# Altium schematic sheet POW.SchDoc(POW)
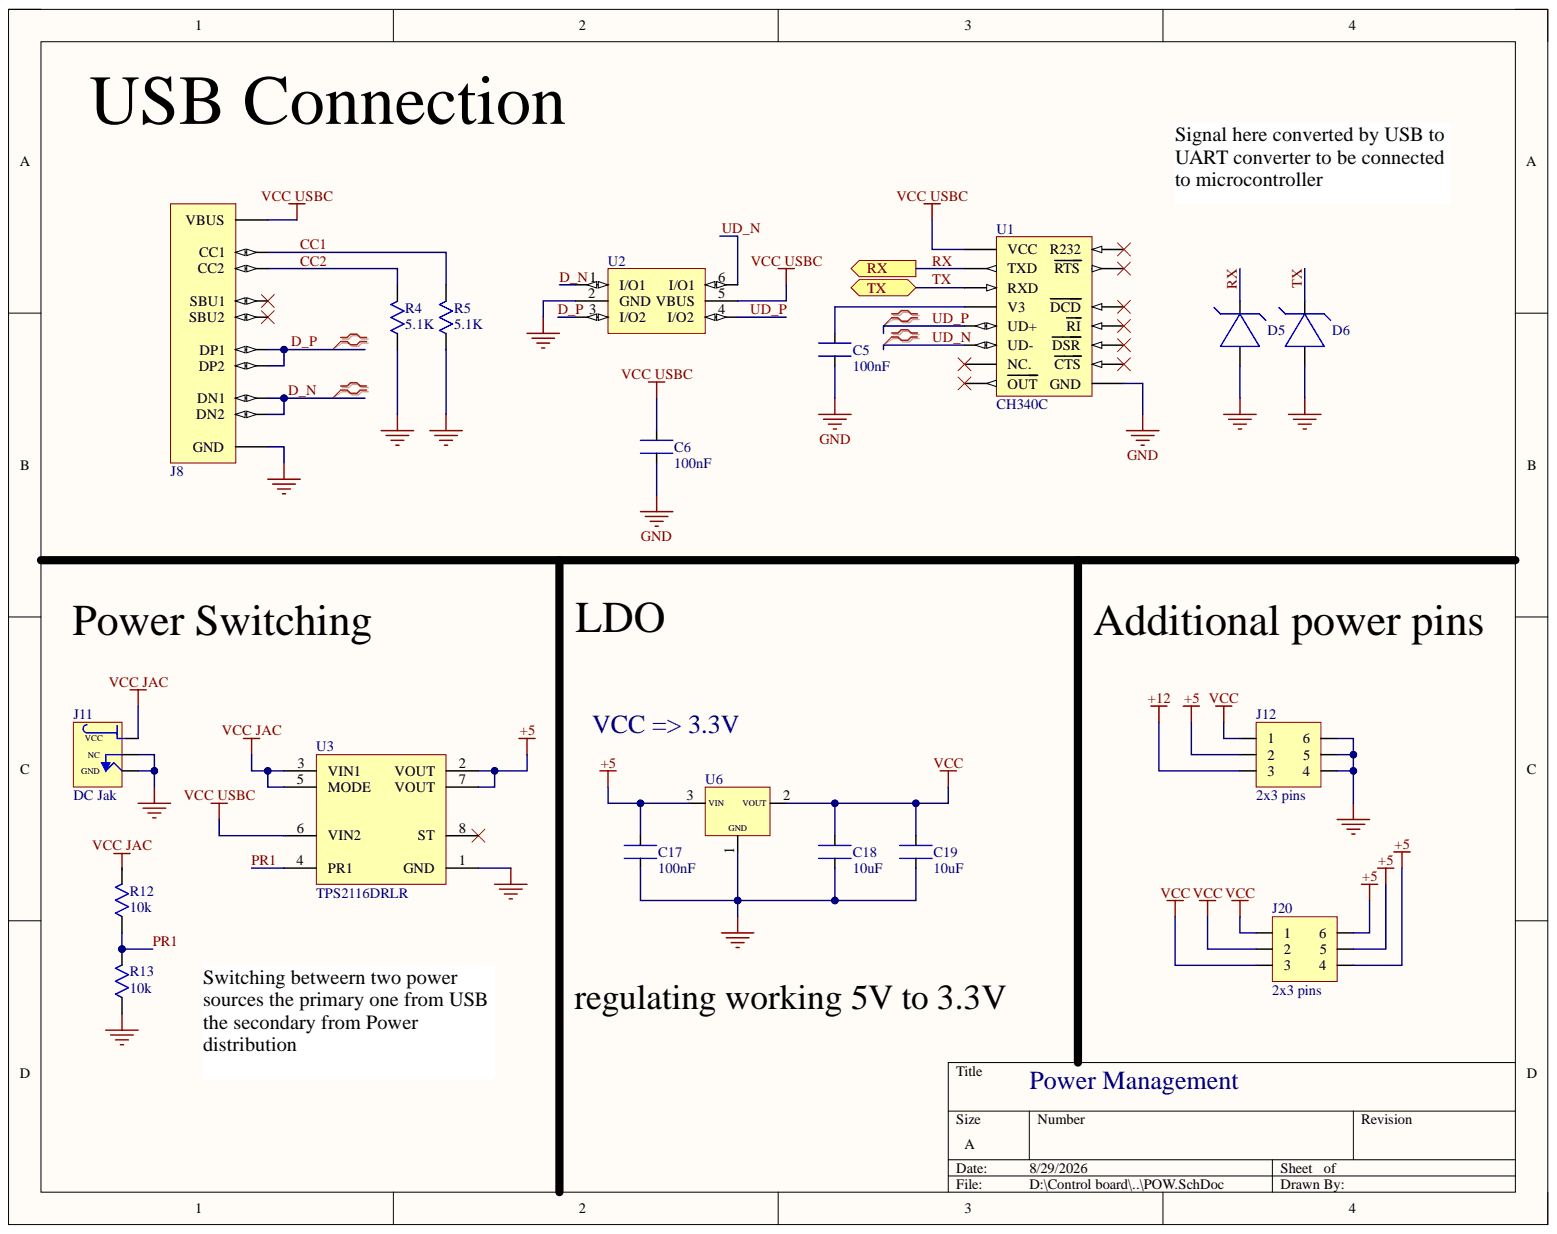
[diagram p0: POW.SchDoc]

## Parts
C5  100nF  [0603 CAP]
C6  100nF  [0603 CAP]
C17  100nF  [0603 CAP]
C18  10uF  [0603 CAP]
C19  10uF  [0603 CAP]
D5  ESD protection diode  [ESD protection diode]  (value not drawn)
D6  ESD protection diode  [ESD protection diode]  (value not drawn)
J8  USB TYPE-C-31-M-12  [USB Type-C]  (value not drawn)
J11  DC Jak  [DC Jack]
J12  2x3 pins  [2x3 pins]
J20  2x3 pins  [2x3 pins]
R4  5.1K  [0603 RES]
R5  5.1K  [0603 RES]
R12  10k  [0603 RES]
R13  10k  [0603 RES]
U1  CH340C  [CH340C]
U2  usb tybc esd  [usb c esd]  (value not drawn)
U3  TPS2116DRLR  [power distribution]
U6  ST LDO 3.3 regulator  [ST_LDO]  (value not drawn)

## Ports
RX, TX

## Nets
+5: C17.1 J12.2 J20.4 J20.5 J20.6 U3.2(VOUT) U3.7(VOUT) U6.3
+12: J12.3
GND: C5.2 C6.2 C17.2 C18.2 C19.2 D5.1 D6.1 J8.0(GND) J11.2 J11.3 J12.4 J12.5 J12.6 R4.1 R5.1 R13.1 U1.1(GND) U2.2(GND) U3.1(GND) U6.1
VCC: C18.1 C19.1 J12.1 J20.1 J20.2 J20.3 U6.2
VCC JAC: J11.1 R12.2 U3.3(VIN1) U3.5(MODE)
VCC USBC: C6.1 J8.VBUS U1.16(VCC) U2.5(VBUS) U3.6(VIN2)
CC1: J8.A5(CC1) R5.2
CC2: J8.B5(CC2) R4.2
D_N: J8.A7(DN1) J8.B7(DN2) U2.1(I/O1)
D_P: J8.A6(DP1) J8.B6(DP2) U2.3(I/O2)
PR1: R12.1 R13.2 U3.4(PR1)
RX: D5.2 U1.2(TXD) ; port RX
TX: D6.2 U1.3(RXD) ; port TX
UD_N: U1.6(UD-) U2.6(I/O1)
UD_P: U1.5(UD+) U2.4(I/O2)
NetC5_1: C5.1 U1.4(V3)

Not connected: J8 A8(SBU1) B8(SBU2); U1 7(NC.) 8(OUT) 9(CTS) 10(DSR) 11(RI) 12(DCD) 14(RTS) 15(R232); U3 8(ST)

## Library data of the parts
C5 C6 C17 = 100nF: 50V 100nF X7R ±10% 0603 Multilayer Ceramic Capacitors MLCC - SMD/SMT ROHS; Manufacturer 1: YAGEO; Manufacturer Part Number 1: CC0603KRX7R9BB104; Component Kind: Standard; Library Name: Schlib1.SchLib; Link: https://www.lcsc.com/product-detail/Multilayer-Ceramic-Capacitors-MLCC-SMD-SMT_YAGEO-CC0603KRX7R9BB104_C14663.html; Supplier 1: LCSC; Supplier Part Number 1: C14663
C18 C19 = 10uF: 6.3V 10uF X5R ±20% 0603 Multilayer Ceramic Capacitors MLCC - SMD/SMT ROHS; Manufacturer 1: YAGEO; Manufacturer Part Number 1: CC0603MRX5R5BB106; Component Kind: Standard; Library Name: Schlib1.SchLib; Link: https://www.lcsc.com/product-detail/Multilayer-Ceramic-Capacitors-MLCC-SMD-SMT_YAGEO-CC0603MRX5R5BB106_C109454.html; Supplier 1: LCSC; Supplier Part Number 1: C109454
D5 D6 = ESD protection diode: SOT-5X3 ESD and Surge Protection (TVS/ESD) ROHS; Manufacturer 1: Texas Instruments; Manufacturer Part Number 1: ESD321DYAR; Component Kind: Standard; Library Name: Schlib1.SchLib; Link: https://www.lcsc.com/product-detail/ESD-and-Surge-Protection-TVS-ESD_Texas-Instruments-ESD321DYAR_C20345394.html; Supplier 1: LCSC; Supplier Part Number 1: C20345394
J8 = USB TYPE-C-31-M-12: 5A 1 16P Female Type-C SMD USB Connectors ROHS; Manufacturer 1: Korean Hroparts Elec; Component Kind: Standard; Library Name: Schlib1.SchLib; Link: https://www.lcsc.com/product-detail/USB-Connectors_Korean-Hroparts-Elec-TYPE-C-31-M-12_C165948.html; Manufacturer Part Number 1: TYPE-C-31-M-12; Supplier 1: LCSC; Supplier Part Number 1: C165948
J11 = DC Jak: Bend-in DC Power Receptacle -20℃~+70℃ 2.5mm 6.5mm 5A 24V Plugin DC Power Connectors ROHS; Manufacturer 1: XKB Connection; Manufacturer Part Number 1: DC-005-5A-2.5; Component Kind: Standard; Library Name: Schlib1.SchLib; Link: https://www.lcsc.com/product-detail/DC-Power-Connectors_XKB-Connection-DC-005-5A-2-5_C381115.html?s_z=n_DC%2520Power%2520Receptacle%25202.5mm%25206.4mm%25205A%2520%2520Plugin%2520DC%2520Power%2520Connectors%2520ROHS; Supplier 1: LCSC; Supplier Part Number 1: C381115
J12 J20 = 2x3 pins: Straight 2.54mm 6mm 6P 3mm 2.54mm 双排 2x3P Plugin,P=2.54mm Pin Headers ROHS; Manufacturer 1: HanElectricity; Manufacturer Part Number 1: 2541WV-2x03P; Component Kind: Standard; Library Name: Schlib1.SchLib; supplier 1: LCSC; Supplier Part Number 1: C5383104
R4 R5 = RES 5.1 K: 100mW Thin Film Resistor 75V ±25ppm/℃ ±0.1% 5.1kΩ 0603 Chip Resistor - Surface Mount ROHS; Manufacturer 1: YAGEO; Manufacturer Part Number 1: RT0603BRD075K1L; Component Kind: Standard; Library Name: Schlib1.SchLib; Link: https://www.lcsc.com/product-detail/Chip-Resistor-Surface-Mount_YAGEO-RT0603BRD075K1L_C122969.html; Supplier 1: LCSC; Supplier Part Number 1: C122969
R12 R13 = RES 10kohm: 100mW Thin Film Resistor 75V ±25ppm/℃ ±0.1% 10kΩ 0603 Chip Resistor - Surface Mount ROHS; Manufacturer 1: YAGEO; Manufacturer Part Number 1: RT0603BRD0710KL; Component Kind: Standard; Library Name: Schlib1.SchLib; Link: https://www.lcsc.com/product-detail/Chip-Resistor-Surface-Mount_YAGEO-RT0603BRD0710KL_C95204.html?s_z=n_100mW%2520Thin%2520Film%2520Resistor%252075V%2520%25C2%25B125ppm%252F%25E2%2584%2583%2520%25C2%25B10.1%2525%252010k%25CE%25A9%25200603%2520Chip%2520Resistor%2520-%2520Surface%2520Mount%2520ROHS; Supplier 1: LCSC; Supplier Part Number 1: C95204
U1 = UART CONV. USB: 2Mbps Transceiver USB 2.0 SOP-16 USB Converters ROHS; Manufacturer 1: WCH(Jiangsu Qin Heng); Component Kind: Standard; Library Name: Schlib1.SchLib; Manufacturer Part Number 1: CH340C; Supplier 1: LCSC; Supplier Part Number 1: C84681
U2 = usb tybc esd: SOT-23-6 ESD and Surge Protection (TVS/ESD) ROHS; Manufacturer 1: TECH PUBLIC; Manufacturer Part Number 1: TPUSBLC6-2SC6; Component Kind: Standard; Library Name: Schlib1.SchLib; Link: https://www.lcsc.com/product-detail/ESD-and-Surge-Protection-TVS-ESD_TECH-PUBLIC-TPUSBLC6-2SC6_C558442.html; Supplier 1: LCSC; Supplier Part Number 1: C558442
U3 = power distribution: 1.6V~5.5V 42mΩ 2.5A 1 SOT-583 Power Distribution Switches ROHS; Manufacturer 1: Texas Instruments; Component Kind: Standard; Library Name: Schlib1.SchLib; Link: https://www.lcsc.com/product-detail/Power-Distribution-Switches_Texas-Instruments-TPS2116DRLR_C3235557.html; Manufacturer Part Number 1: TPS2116DRLR; Supplier 1: LCSC; Supplier Part Number 1: C3235557
U6 = ST LDO 3.3 regulator: 1A Fixed 3.3V Positive electrode 15V SOT-223-4 Voltage Regulators - Linear, Low Drop Out (LDO) Regulators ROHS; Manufacturer 1: STMicroelectronics; Manufacturer Part Number 1: LD1117AS33TR; Component Kind: Standard; Library Name: Schlib1.SchLib; Link: https://www.lcsc.com/product-detail/Voltage-Regulators-Linear-Low-Drop-Out-LDO-Regulators_STMicroelectronics-LD1117AS33TR_C115288.html; Supplier 1: LCSC; Supplier Part Number 1: C115288
